# Altium schematic sheet RF_CC1101.SchDoc(RF_CC1101)
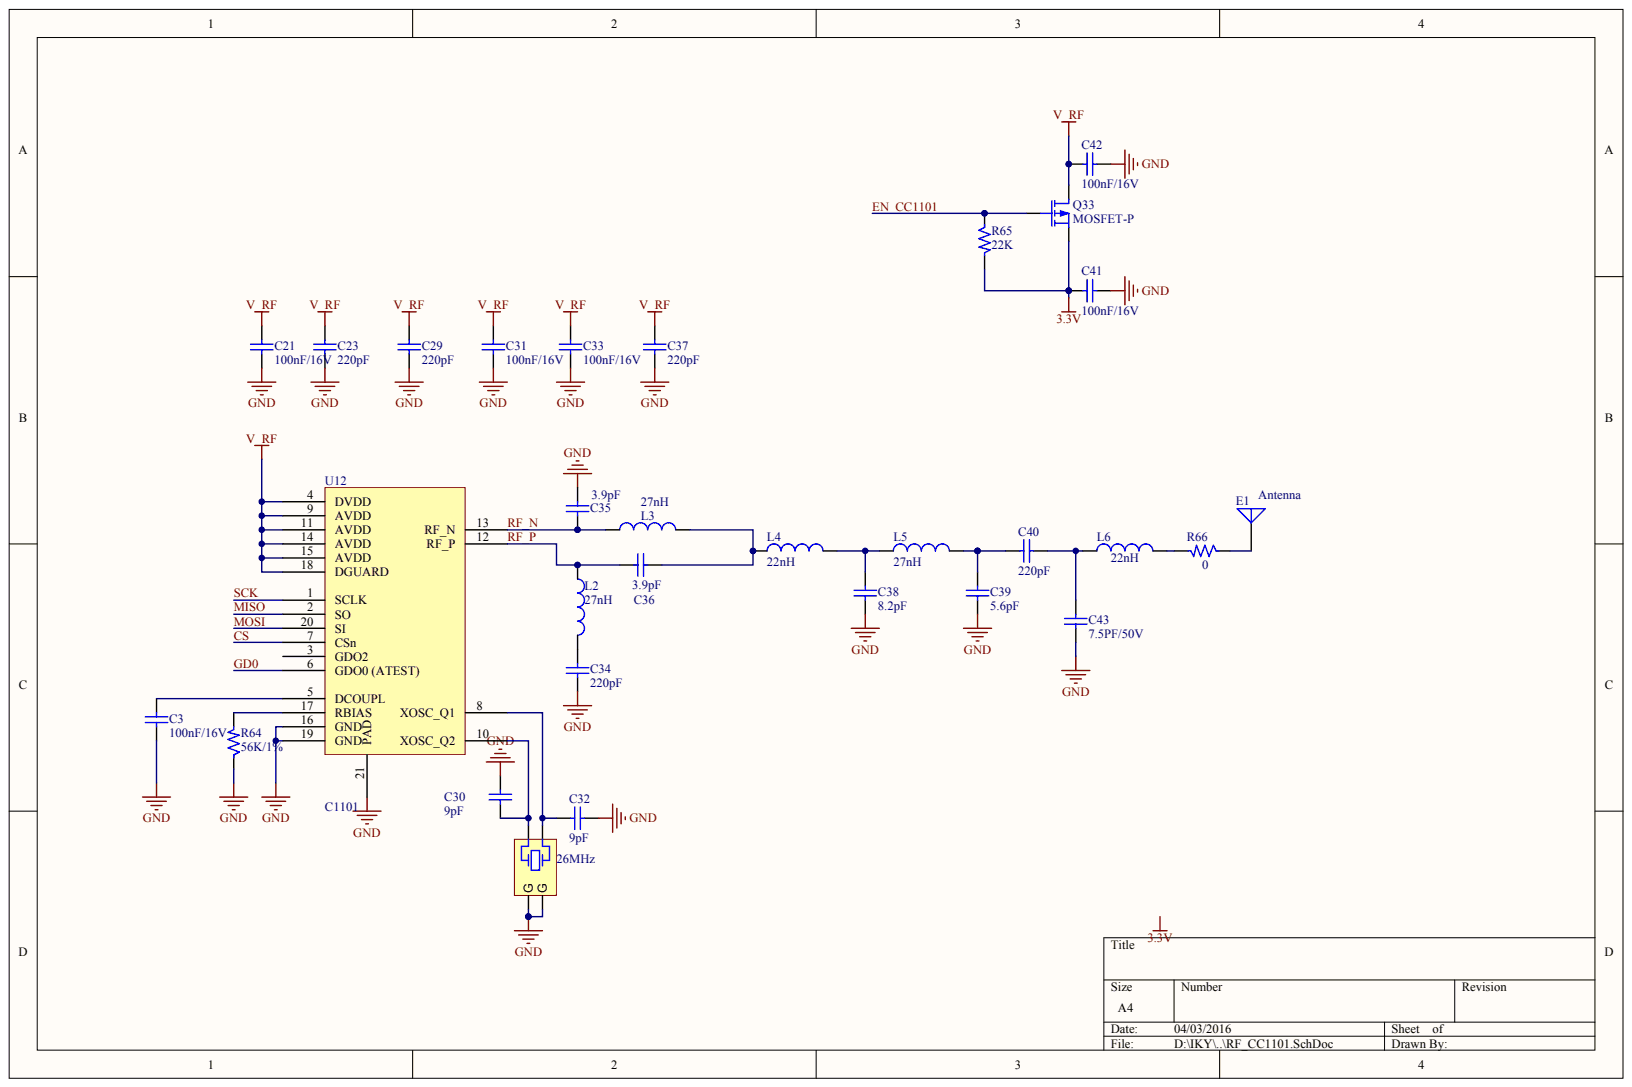
[diagram p0: RF_CC1101.SchDoc]

## Parts
C3  100nF/16V
C21  100nF/16V
C23  220pF
C29  220pF
C30  9pF
C31  100nF/16V
C32  9pF
C33  100nF/16V
C34  220pF
C35  3.9pF
C36  3.9pF
C37  220pF
C38  8.2pF
C39  5.6pF
C40  220pF
C41  100nF/16V
C42  100nF/16V
C43  7.5PF/50V
E1  Antenna
L2  27nH
L3  27nH
L4  22nH
L5  27nH
L6  22nH
Q33  MOSFET-P
R64  56K/1%
R65  22K
R66  0
U12  C1101
Y2  26MHz

## Nets
3.3V: C41.2 Q33.2 R65.2
GND: C3.1 C21.2 C23.2 C29.2 C30.2 C31.2 C32.1 C33.2 C34.1 C35.1 C37.2 C38.1 C39.1 C41.1 C42.1 C43.2 R64.1 U12.16(GND) U12.19(GND) U12.21(PAD) Y2.2(G) Y2.4(G)
V_RF: C21.1 C23.1 C29.1 C31.1 C33.1 C37.1 C42.2 Q33.3 U12.4(DVDD) U12.9(AVDD) U12.11(AVDD) U12.14(AVDD) U12.15(AVDD) U12.18(DGUARD)
CS: U12.7(CSn)
EN_CC1101: Q33.1 R65.1
GD0: U12.6(GDO0_(ATEST))
MISO: U12.2(SO)
MOSI: U12.20(SI)
RF_N: C35.2 L3.1 U12.13(RF_N)
RF_P: C36.2 L2.1 U12.12(RF_P)
SCK: U12.1(SCLK)
NetC3_2: C3.2 U12.5(DCOUPL)
NetC30_1: C30.1 U12.10(XOSC_Q2) Y2.1
NetC32_2: C32.2 U12.8(XOSC_Q1) Y2.3
NetC34_2: C34.2 L2.2
NetC36_1: C36.1 L3.2 L4.1
NetC38_2: C38.2 L4.2 L5.1
NetC39_2: C39.2 C40.2 L5.2
NetC40_1: C40.1 C43.1 L6.1
NetE1_1: E1.1 R66.1
NetL6_2: L6.2 R66.2
NetR64_2: R64.2 U12.17(RBIAS)

Not connected: U12 3(GDO2)

## Library data of the parts
C3 C21 C23 C29-C43 = (no symbol name): Manufacturer: Yageo; Value: 100nF/50V; Unit Price: *; Manufacturer P/N: *; PackageReference: *; Digi-Key P/N: *; Mouser P/N: *; Vender: *; Publisher: Cao Van Huong, vanhuongcao@gmail.com
L2-L6 = (no symbol name): Value: 150 mH; Manufacturer P/N: *; Manufacturer: *; Unit Price: *; PackageReference: *; Digi-Key P/N: *; Mouser P/N: *; Vender: *; Publisher: Cao Van Huong, vanhuongcao@gmail.com
R64-R66 = (no symbol name): Manufacturer: Yageo; Value: 10K; Manufacturer P/N: *; Unit Price: *; PackageReference: R0805; Digi-Key P/N: *; Mouser P/N: *; Vender: *; Publisher: Cao Van Huong, vanhuongcao@gmail.com
U12 = (no symbol name): Manufacturer: Texas Instruments; Manufacturer P/N: CC1101RGPR; Unit Price: *; Value: C1101; PackageReference: QFN20; Digi-Key P/N: *; Mouser P/N: *; Vender: *; Publisher: Cao Van Huong, vanhuongcao@gmail.com
E1 Q33 Y2 = (no symbol name)
  E1: Published: 24-Mar-1999; LatestRevisionDate: 17-Jul-2002; LatestRevisionNote: Re-released for DXP Platform.; PackageReference: PIN1; Publisher: Altium Limited
  Q33: Manufacturer P/N: *; Value: *; PackageReference: *; Manufacturer: *; Unit Price: *; Digi-Key P/N: *; Mouser P/N: *; Vender: *; Publisher: Cao Van Huong, vanhuongcao@gmail.com
  Y2: Unit Price: *; Manufacturer P/N: *; Manufacturer: *; PackageReference: *; Digi-Key P/N: *; Mouser P/N: *; Vender: *; Publisher: *; Publisher: Cao Van Huong, vanhuongcao@gmail.com
